# Altium schematic sheet Power.SchDoc(Power) (continued)
## Library data of the parts (continued)
R10 = RC0603JR-07300RL: Res Thick Film 0603 300 Ohm 5% 0.1W(1/10W) ±100ppm/C Pad SMD T/R; Manufacturer: Yageo Group; Power Dissipation: 100mW; Temperature Coefficient: 100ppm/°C; Value: 300Ω; Voltage Rating: 75V; Voltage Rating (DC): 50V; Case Code (Imperial): 0603; Case/Package: 0603; Category: Chip SMD Resistors; China RoHS: Non-Compliant; Component Kind: Standard; ComponentLink1Description: https://datasheet.octopart.com:443/RC0603JR-07300RL-Yageo-datasheet-175887523.pdf?src-supplier=IHS; ComponentLink1URL: https://datasheet.octopart.com:443/RC0603JR-07300RL-Yageo-datasheet-175887523.pdf?src-supplier=IHS; ComponentLink2Description: https://datasheet.octopart.com:443/RC0603JR-07300RL-Yageo-datasheet-98239111.pdf?src-supplier=Upverter; ComponentLink2URL: https://datasheet.octopart.com:443/RC0603JR-07300RL-Yageo-datasheet-98239111.pdf?src-supplier=Upverter; ComponentLink3Description: https://datasheet.octopart.com:443/RC0603JR-07300RL-Yageo-datasheet-8328172.pdf?src-supplier=TME; ComponentLink3URL: https://datasheet.octopart.com:443/RC0603JR-07300RL-Yageo-datasheet-8328172.pdf?src-supplier=TME; ComponentLink4Description: https://datasheet.octopart.com:443/RC0603JR-07300RL-Yageo-datasheet-34211833.pdf?src-supplier=element14+APAC; ComponentLink4URL: https://datasheet.octopart.com:443/RC0603JR-07300RL-Yageo-datasheet-34211833.pdf?src-supplier=element14+APAC; ComponentLink5Description: https://datasheet.octopart.com:443/RC0603JR-07300RL-Yageo-datasheet-62067350.pdf?src-supplier=Farnell; ComponentLink5URL: https://datasheet.octopart.com:443/RC0603JR-07300RL-Yageo-datasheet-62067350.pdf?src-supplier=Farnell; ComponentLink6Description: https://datasheet.octopart.com:443/RC0603JR-07300RL-Yageo-Group-datasheet-176638170.pdf?src-supplier=Future+Electronics; ComponentLink6URL: https://datasheet.octopart.com:443/RC0603JR-07300RL-Yageo-Group-datasheet-176638170.pdf?src-supplier=Future+Electronics; ComponentLink7Description: https://datasheet.octopart.com:443/RC0603JR-07300RL-Yageo-datasheet-164759695.pdf?src-supplier=Future+Electronics; ComponentLink7URL: https://datasheet.octopart.com:443/RC0603JR-07300RL-Yageo-datasheet-164759695.pdf?src-supplier=Future+Electronics; ComponentLink8Description: https://datasheet.octopart.com:443/RC0603JR-07300RL-Yageo-datasheet-137700087.pdf?src-supplier=Future+Electronics; ComponentLink8URL: https://datasheet.octopart.com:443/RC0603JR-07300RL-Yageo-datasheet-137700087.pdf?src-supplier=Future+Electronics; ComponentLink9Description: https://datasheet.octopart.com:443/RC0603JR-07300RL-Yageo-datasheet-15599030.pdf?src-supplier=Future+Electronics; ComponentLink9URL: https://datasheet.octopart.com:443/RC0603JR-07300RL-Yageo-datasheet-15599030.pdf?src-supplier=Future+Electronics; ComponentLink10Description: https://datasheet.octopart.com:443/RC0603JR-07300RL-Yageo-datasheet-12596169.pdf?src-supplier=Future+Electronics; ComponentLink10URL: https://datasheet.octopart.com:443/RC0603JR-07300RL-Yageo-datasheet-12596169.pdf?src-supplier=Future+Electronics; ComponentLink11Description: https://datasheet.octopart.com:443/RC0603JR-07300RL-Yageo-datasheet-8324193.pdf?src-supplier=Future+Electronics; ComponentLink11URL: https://datasheet.octopart.com:443/RC0603JR-07300RL-Yageo-datasheet-8324193.pdf?src-supplier=Future+Electronics; ComponentLink12Description: https://datasheet.octopart.com/RC0603JR-07300RL-Yageo-datasheet-73766026.pdf; ComponentLink12URL: https://datasheet.octopart.com/RC0603JR-07300RL-Yageo-datasheet-73766026.pdf; ComponentLink13Description: https://datasheet.octopart.com:443/RC0603JR-07300RL-Yageo-datasheet-118562.pdf?src-supplier=DigiKey; ComponentLink13URL: https://datasheet.octopart.com:443/RC0603JR-07300RL-Yageo-datasheet-118562.pdf?src-supplier=DigiKey; ComponentLink14Description: http://sigma.octopart.com:80/conflict_mineral_statement/Yageo-company-3.pdf?src-supplier=Compliance+Statements; ComponentLink14URL: http://sigma.octopart.com:80/conflict_mineral_statement/Yageo-company-3.pdf?src-supplier=Compliance+Statements; ComponentLink15Description: http://sigma.octopart.com:80/rohs_statement/Yageo-company-2.pdf?src-supplier=Compliance+Statements; ComponentLink15URL: http://sigma.octopart.com:80/rohs_statement/Yageo-company-2.pdf?src-supplier=Compliance+Statements; Composition: Thick Film; Country of Origin: Mainland China, Taiwan; Depth: 800µm; Export Control Classification Number (ECCN) Code: EAR99; Harmonized Tariff Schedule (HTS) Code: 8533.21.00.30; Height: 450µm; Introduction Date: 2004-09-22; Lead Free: Lead Free; Length: 1.6mm; Library Name: ENGG3800 schematic library.SchLib; Lifecycle Status: Production; Manufacturer Part Number: RC0603JR-07300RL; Max Operating Temperature: 155°C; Military Standard: Not; Min Operating Temperature: -55°C; Mounting Technology: Surface Mount; Number of Terminals: 2; Pins: 2; Power Rating: 100mW; Pricing: 5000=0.0015, 10000=0.0012 (USD); REACH SVHC: Yes; RoHS: Compliant; Schedule B: 8533210045, 8533210045|8533210045|8533210045|8533210045|8533210045|8533210045|8533210045|8533210045|8533210045|8533210045|8533210045|8533210045|8533210045|8533210045|8533210045|8533210045|8533210045|8533210045|8533210045|8533210045|8533210045|8533210045|8533210045|85; Stock: 640000; Supplier 1: Digikey; Supplier Part Number 1: 311-300GRCT-ND; Termination: SMD/SMT; Weight: 2.012816mg; Width: 800µm; Working Voltage: 75V
SW1 = CS22BNW03: Switch Slide ON None ON DPDT Top Slide 3A 250VAC 50000Cycles PC Pins Thru-Hole; Manufacturer: NKK Switches; Actuator Length: 5.1054mm; Actuator Material: Glass, Glass fibre, Nylon, Polyamide; Contact Resistance: 10mΩ; Contact Style: Non-Shorting; Contact Voltage Rating (AC): 125V; Electrical Life: 20kcycles; Illumination Type: Non-Illuminated; Insulation Resistance: 1GΩ; Max Voltage Rating (AC): 250V; Mechanical Life: 50kcycles; Number of Positions: 2; Sealable: EPOXY TERMINAL SEALED; Switch Function: On-On; Switch Travel: 2.2mm; Category: Slide Switches; China RoHS: Compliant; Component Kind: Standard; ComponentLink1Description: https://datasheet.octopart.com:443/CS22BNW03-NKK-Switches-datasheet-12526506.pdf?src-supplier=Upverter; ComponentLink1URL: https://datasheet.octopart.com:443/CS22BNW03-NKK-Switches-datasheet-12526506.pdf?src-supplier=Upverter; ComponentLink2Description: https://datasheet.octopart.com:443/CS22BNW03-NKK-Switches-datasheet-123473.pdf?src-supplier=Future+Electronics; ComponentLink2URL: https://datasheet.octopart.com:443/CS22BNW03-NKK-Switches-datasheet-123473.pdf?src-supplier=Future+Electronics; ComponentLink3Description: https://datasheet.octopart.com:443/CS22BNW03-NKK-Switches-datasheet-110754214.pdf?src-supplier=TME; ComponentLink3URL: https://datasheet.octopart.com:443/CS22BNW03-NKK-Switches-datasheet-110754214.pdf?src-supplier=TME; ComponentLink4Description: https://datasheet.octopart.com:443/CS22BNW03-NKK-Switches-datasheet-137092470.pdf?src-supplier=X-ON; ComponentLink4URL: https://datasheet.octopart.com:443/CS22BNW03-NKK-Switches-datasheet-137092470.pdf?src-supplier=X-ON; ComponentLink5Description: http://sigma.octopart.com:80/rohs_statement/NKK-Switches-company-77.pdf?src-supplier=Compliance+Statements; ComponentLink5URL: http://sigma.octopart.com:80/rohs_statement/NKK-Switches-company-77.pdf?src-supplier=Compliance+Statements; ComponentLink6Description: http://sigma.octopart.com:80/reach_statement/NKK-Switches-company-76.pdf?src-supplier=Compliance+Statements; ComponentLink6URL: http://sigma.octopart.com:80/reach_statement/NKK-Switches-company-76.pdf?src-supplier=Compliance+Statements; ComponentLink7Description: http://sigma.octopart.com:80/conflict_mineral_statement/NKK-Switches-company-75.pdf?src-supplier=Compliance+Statements; ComponentLink7URL: http://sigma.octopart.com:80/conflict_mineral_statement/NKK-Switches-company-75.pdf?src-supplier=Compliance+Statements; Contact Current Rating: 3A; Contact Material: Copper; Contact Plating: Silver; Current Rating: 3A; Depth: 11.9mm; Export Control Classification Number (ECCN) Code: EAR99; Flammability Rating: UL94 V-0; Harmonized Tariff Schedule (HTS) Code: 8536.50.90.50; Height: 9.7mm; Introduction Date: 2010-05-04; Lead Free: Lead Free; Length: 14mm; Library Name: ENGG3800 schematic library.SchLib; Life (Cycles): 20kcycles; Lifecycle Status: Production; Manufacturer Part Number: CS22BNW03; Max Current Rating: 3A; Max Operating Temperature: 85°C; Min Operating Temperature: -30°C; Mounting Technology: Through Hole; Orientation: Vertical; Pricing: 50=1.47, 150=1.46, 500=1.44, 1000=1.42, 2000=1.4 (USD); REACH SVHC: Yes; RoHS: Compliant; Stock: 789; Supplier 1: Digikey; Supplier Part Number 1: 360-2132-ND; Terminal Material: Copper; Termination: PC Pins; Throw Configuration: DPDT; Voltage Rating (AC): 125V
TP1 TP6 = 5010: MS27478 - Connectors Receptacle Electrical Solder Mounting Solder Type Hermetic Seal 38999 Series II; Manufacturer: Keystone Electronics; Body Material: Bronze,PBT; Hole Diameter: 1.6mm; Inside Diameter: 1.78mm; Plating: Silver; Category: Connectors; Color: Red; Component Kind: Standard; ComponentLink1Description: https://datasheet.ciiva.com/11744/0900766b8009b7c7-11744920.pdf?src-supplier=Rs; ComponentLink1URL: https://datasheet.ciiva.com/11744/0900766b8009b7c7-11744920.pdf?src-supplier=Rs; ComponentLink2Description: https://datasheet.ciiva.com/2917/5010-2917626.pdf?src-supplier=Digikey; ComponentLink2URL: https://datasheet.ciiva.com/2917/5010-2917626.pdf?src-supplier=Digikey; ComponentLink3Description: https://datasheet.ciiva.com/1454/1703983-1454833.pdf?src-supplier=Element14; ComponentLink3URL: https://datasheet.ciiva.com/1454/1703983-1454833.pdf?src-supplier=Element14; ComponentLink4Description: https://datasheet.ciiva.com/22631/1703983-22631113.pdf?src-supplier=Element14; ComponentLink4URL: https://datasheet.ciiva.com/22631/1703983-22631113.pdf?src-supplier=Element14; ComponentLink5Description: https://datasheet.ciiva.com/16506/m60-2p60-16506972.pdf?src-supplier=Future+Electronics; ComponentLink5URL: https://datasheet.ciiva.com/16506/m60-2p60-16506972.pdf?src-supplier=Future+Electronics; ComponentLink6Description: https://datasheet.ciiva.com/16883/70182825-16883886.pdf?src-supplier=Allied+Electronics; ComponentLink6URL: https://datasheet.ciiva.com/16883/70182825-16883886.pdf?src-supplier=Allied+Electronics; Contact Material: Bronze; Contact Plating: Silver,Tin; Flammability Rating: UL94 V-0; Height: 0.445inch; Insulation Material: Nylon; Lead Free: Lead Free; Length: 11.3mm; Manufacturer Part Number: 5010; Material: Nylon,Bronze,Silver; Mounting Technology: Through Hole,PCB; Package Quantity: 100; Packaging: Bulk; Pricing: 1=0.39, 10=0.293, 25=0.2624, 100=0.2223, 500=0.18446, 1000=0.1739, 2500=0.1542, 5000=0.14312 (USD); Reach SVHC Compliant: No; RoHS: Yes; RoHS Compliant: Yes; Series: THM; Stock: 151577; Supplier 1: Digikey; Supplier Part Number 1: 36-5010-ND; Termination: Through Hole; Library Name: tpmania.SchLib
TP2 = 5012: Test Point, Multipurpose, Thm, .350 L, .125 Od, Heat Resistant Nylon 46, White; Manufacturer: Keystone; Body Material: Bronze, PBT; Gender: Female; Hole Diameter: 1.6mm; Inside Diameter: 1.78mm; Insulation: Insulated; Number of Contacts: 1; Case/Package: 5012; Category: Test Points; Color: White; Component Kind: Standard; ComponentLink1Description: https://datasheet.octopart.com:443/5012-Keystone-datasheet-111020743.pdf?src-supplier=IHS; ComponentLink1URL: https://datasheet.octopart.com:443/5012-Keystone-datasheet-111020743.pdf?src-supplier=IHS; ComponentLink2Description: https://datasheet.octopart.com:443/5012-Keystone-datasheet-15716159.pdf?src-supplier=Farnell; ComponentLink2URL: https://datasheet.octopart.com:443/5012-Keystone-datasheet-15716159.pdf?src-supplier=Farnell; ComponentLink3Description: https://datasheet.octopart.com:443/5012-Keystone-datasheet-9590016.pdf?src-supplier=Sager+Electronics; ComponentLink3URL: https://datasheet.octopart.com:443/5012-Keystone-datasheet-9590016.pdf?src-supplier=Sager+Electronics; ComponentLink4Description: https://datasheet.octopart.com:443/5012-Keystone-datasheet-23674.pdf?src-supplier=element14; ComponentLink4URL: https://datasheet.octopart.com:443/5012-Keystone-datasheet-23674.pdf?src-supplier=element14; ComponentLink5Description: https://datasheet.octopart.com:443/5012-Keystone-datasheet-549598.pdf?src-supplier=Future+Electronics; ComponentLink5URL: https://datasheet.octopart.com:443/5012-Keystone-datasheet-549598.pdf?src-supplier=Future+Electronics; ComponentLink6Description: https://datasheet.octopart.com:443/5012-Keystone-datasheet-60278094.pdf?src-supplier=Future+Electronics; ComponentLink6URL: https://datasheet.octopart.com:443/5012-Keystone-datasheet-60278094.pdf?src-supplier=Future+Electronics; ComponentLink7Description: https://datasheet.octopart.com:443/5012-Keystone-datasheet-528293.pdf?src-supplier=Future+Electronics; ComponentLink7URL: https://datasheet.octopart.com:443/5012-Keystone-datasheet-528293.pdf?src-supplier=Future+Electronics; ComponentLink8Description: https://datasheet.octopart.com:443/5012-Keystone-datasheet-10925273.pdf?src-supplier=RS+(Formerly+Allied+Electronics); ComponentLink8URL: https://datasheet.octopart.com:443/5012-Keystone-datasheet-10925273.pdf?src-supplier=RS+(Formerly+Allied+Electronics); ComponentLink9Description: https://datasheet.octopart.com:443/5012-Keystone-datasheet-6528.pdf?src-supplier=RS+(Formerly+Allied+Electronics); ComponentLink9URL: https://datasheet.octopart.com:443/5012-Keystone-datasheet-6528.pdf?src-supplier=RS+(Formerly+Allied+Electronics); ComponentLink10Description: https://datasheet.octopart.com:443/5012-Keystone-datasheet-7282928.pdf?src-supplier=Jameco; ComponentLink10URL: https://datasheet.octopart.com:443/5012-Keystone-datasheet-7282928.pdf?src-supplier=Jameco; Contact Material: Bronze; Contact Plating: Silver; Country of Origin: Mainland China; Diameter: 3.18mm; Export Control Classification Number (ECCN) Code: EAR99; Flammability Rating: UL94 V-0; Height: 8.12mm; Housing Color: White; Introduction Date: 1995-10-01; Lead Free: Lead Free; Length: 11.3mm; Lifecycle Status: Production; Manufacturer Part Number: 5012; Material: Bronze, Nylon, Silver; Mounting Technology: Through Hole; Number of Ports: 1; Orientation: Straight; Packaging: Bulk; Pricing: 125=0.184, 250=0.181, 500=0.178, 1250=0.175, 2500=0.17 (USD); REACH SVHC: Yes; RoHS: Compliant; Stock: 1107; Supplier 1: Digikey; Supplier Part Number 1: 36-5012-ND; Termination: Through Hole; Library Name: tpmania.SchLib
TP3 = 5013: Test Point, Multipurpose, Thm, .350 L, .125 Od, Heat Resistant Nylon 46, Orange; Manufacturer: Keystone; Body Material: PBT; Inside Diameter: 1.78mm; Category: Test Points; Color: Orange; Component Kind: Standard; ComponentLink1Description: https://datasheet.octopart.com:443/5013-Keystone-datasheet-111020744.pdf?src-supplier=IHS; ComponentLink1URL: https://datasheet.octopart.com:443/5013-Keystone-datasheet-111020744.pdf?src-supplier=IHS; ComponentLink2Description: https://datasheet.octopart.com:443/5013-Keystone-datasheet-15716159.pdf?src-supplier=Farnell; ComponentLink2URL: https://datasheet.octopart.com:443/5013-Keystone-datasheet-15716159.pdf?src-supplier=Farnell; ComponentLink3Description: https://datasheet.octopart.com:443/5013-Keystone-datasheet-23674.pdf?src-supplier=element14; ComponentLink3URL: https://datasheet.octopart.com:443/5013-Keystone-datasheet-23674.pdf?src-supplier=element14; ComponentLink4Description: https://datasheet.octopart.com:443/5013-Keystone-datasheet-9590016.pdf?src-supplier=Sager+Electronics; ComponentLink4URL: https://datasheet.octopart.com:443/5013-Keystone-datasheet-9590016.pdf?src-supplier=Sager+Electronics; ComponentLink5Description: https://datasheet.octopart.com:443/5013-Keystone-datasheet-60278094.pdf?src-supplier=Future+Electronics; ComponentLink5URL: https://datasheet.octopart.com:443/5013-Keystone-datasheet-60278094.pdf?src-supplier=Future+Electronics; ComponentLink6Description: https://datasheet.octopart.com:443/5013-Keystone-datasheet-549598.pdf?src-supplier=Future+Electronics; ComponentLink6URL: https://datasheet.octopart.com:443/5013-Keystone-datasheet-549598.pdf?src-supplier=Future+Electronics; ComponentLink7Description: https://datasheet.octopart.com:443/5013-Keystone-datasheet-10925273.pdf?src-supplier=RS+(Formerly+Allied+Electronics); ComponentLink7URL: https://datasheet.octopart.com:443/5013-Keystone-datasheet-10925273.pdf?src-supplier=RS+(Formerly+Allied+Electronics); ComponentLink8Description: https://datasheet.octopart.com:443/5013-Keystone-datasheet-6528.pdf?src-supplier=RS+(Formerly+Allied+Electronics); ComponentLink8URL: https://datasheet.octopart.com:443/5013-Keystone-datasheet-6528.pdf?src-supplier=RS+(Formerly+Allied+Electronics); ComponentLink9Description: https://datasheet.octopart.com:443/5013-Keystone-datasheet-34151652.pdf?src-supplier=TME; ComponentLink9URL: https://datasheet.octopart.com:443/5013-Keystone-datasheet-34151652.pdf?src-supplier=TME; Contact Material: Bronze; Contact Plating: Silver; Country of Origin: Mainland China; Export Control Classification Number (ECCN) Code: EAR99; Flammability Rating: UL94 V-0; Height: 8.12mm; Introduction Date: 1995-10-01; Lead Free: Lead Free; Length: 11.3mm; Lifecycle Status: Production; Manufacturer Part Number: 5013; Material: Bronze, Nylon, Silver; Mounting Technology: Through Hole; Packaging: Bulk; Pricing: 125=0.173, 250=0.171, 500=0.169, 1500=0.165, 3000=0.16 (USD); REACH SVHC: Yes; RoHS: Compliant; Stock: 2691; Supplier 1: Digikey; Supplier Part Number 1: 36-5013-ND; Termination: Through Hole; Library Name: tpmania.SchLib
TP4 = 5014: MS27478 - Connectors Receptacle Electrical Solder Mounting Solder Type Hermetic Seal 38999 Series II; Manufacturer: Keystone Electronics; Body Material: Bronze,PBT; Inside Diameter: 1.78mm; Plating: Silver; Category: Connectors; Color: Yellow; Component Kind: Standard; ComponentLink1Description: https://datasheet.ciiva.com/2917/5014-2917636.pdf?src-supplier=Digikey; ComponentLink1URL: https://datasheet.ciiva.com/2917/5014-2917636.pdf?src-supplier=Digikey; ComponentLink2Description: https://datasheet.ciiva.com/22631/1703983-22631119.pdf?src-supplier=Element14; ComponentLink2URL: https://datasheet.ciiva.com/22631/1703983-22631119.pdf?src-supplier=Element14; ComponentLink3Description: https://datasheet.ciiva.com/16297/m60-2p60-16297225.pdf?src-supplier=Future+Electronics; ComponentLink3URL: https://datasheet.ciiva.com/16297/m60-2p60-16297225.pdf?src-supplier=Future+Electronics; ComponentLink4Description: https://datasheet.ciiva.com/16884/70182825-16884159.pdf?src-supplier=Allied+Electronics; ComponentLink4URL: https://datasheet.ciiva.com/16884/70182825-16884159.pdf?src-supplier=Allied+Electronics; Contact Material: Bronze; Contact Plating: Silver,Tin; Flammability Rating: UL94 V-0; Height: 0.445inch; Insulation Material: Nylon; Lead Free: Lead Free; Length: 11.3mm; Manufacturer Part Number: 5014; Material: Nylon,Bronze,Silver; Mounting Technology: PCB,Through Hole; Package Quantity: 100; Packaging: Bulk; Pricing: 1=0.39, 10=0.293, 25=0.2624, 100=0.2223, 500=0.18446, 1000=0.1739, 2500=0.1542, 5000=0.14312 (USD); Reach SVHC Compliant: No; RoHS: Yes; RoHS Compliant: Yes; Series: THM; Stock: 92393; Supplier: Digikey; Supplier Part Number: 36-5014-ND; Termination: Through Hole; Library Name: tpmania.SchLib
TP5 = 5011: TEST POINT, PCB, THROUGH HOLE; Product R; Range: -; Connector Colour: Black; Mounting: Through Hole; Manufacturer: Keystone Electronics; Body Material: PBT; Gender: Female; Hole Diameter: 1.6mm; Inside Diameter: 1.78mm; Category: Connectors; Color: Black; Component Kind: Standard; ComponentLink1Description: https://datasheet.ciiva.com/1454/1718230-1454834.pdf?src-supplier=Element14; ComponentLink1URL: https://datasheet.ciiva.com/1454/1718230-1454834.pdf?src-supplier=Element14; ComponentLink2Description: https://datasheet.ciiva.com/11744/0900766b8009b7c7-11744935.pdf?src-supplier=Rs; ComponentLink2URL: https://datasheet.ciiva.com/11744/0900766b8009b7c7-11744935.pdf?src-supplier=Rs; ComponentLink3Description: https://datasheet.ciiva.com/2917/5011-2917635.pdf?src-supplier=Digikey; ComponentLink3URL: https://datasheet.ciiva.com/2917/5011-2917635.pdf?src-supplier=Digikey; ComponentLink4Description: https://datasheet.ciiva.com/46412/1703983-46412138.pdf?src-supplier=Element14; ComponentLink4URL: https://datasheet.ciiva.com/46412/1703983-46412138.pdf?src-supplier=Element14; ComponentLink5Description: https://datasheet.ciiva.com/16351/m60-2p60-16351093.pdf?src-supplier=Future+Electronics; ComponentLink5URL: https://datasheet.ciiva.com/16351/m60-2p60-16351093.pdf?src-supplier=Future+Electronics; Contact Material: Bronze; Contact Plating: Tin,Silver; Flammability Rating: UL94 V-0; Lead Free: 5697LeadFree; Length: 11.3mm; Manufacturer Part Number: 5011; Material: Nylon,Bronze,Silver; Mounting Technology: PCB,Through Hole; Package Quantity: 100; Packaging: Bulk; Pricing: 1=0.39, 10=0.293, 25=0.2624, 100=0.2223, 500=0.18446, 1000=0.1739, 2500=0.1542, 5000=0.14312 (USD); Reach SVHC Compliant: No; RoHS: Yes; RoHS Compliant: Yes; Series: THM; Stock: 252020; Supplier: Digikey; Supplier Part Number: 36-5011-ND; Library Name: tpmania.SchLib
U2 = MCP73831T-2ACI/OT: MCP73831 Series Single-Cell Li-Ion/Li-Polymer Battery Charge Controller SOT-23-5; Manufacturer: Microchip; Manufacturer Lifecycle Status: REL; Drain to Source Resistance: 350mΩ; Max Charge Current: 500mA; Max Junction Temperature (Tj): 125°C; Max Output Voltage: 4.5V; Max Power Dissipation: 1.54W; Max Supply Voltage: 6V; Min Output Voltage: 4.168V; Min Supply Voltage: 3.75V; Number of Cells: 1; Output Voltage: 4.2V; Voltage Rating: 4.534V; Ambient Temperature Range High: 85°C; Case/Package: SOT-23; Category: Battery Management; Component Kind: Standard; ComponentLink1Description: https://datasheet.octopart.com:443/MCP73831T-2ACI-OT-Microchip-datasheet-8814540.pdf?src-supplier=element14+APAC; ComponentLink1URL: https://datasheet.octopart.com:443/MCP73831T-2ACI-OT-Microchip-datasheet-8814540.pdf?src-supplier=element14+APAC; ComponentLink2Description: https://datasheet.octopart.com:443/MCP73831T-2ACI-OT-Microchip-datasheet-145017548.pdf?src-supplier=Microchip; ComponentLink2URL: https://datasheet.octopart.com:443/MCP73831T-2ACI-OT-Microchip-datasheet-145017548.pdf?src-supplier=Microchip; ComponentLink3Description: https://datasheet.octopart.com:443/MCP73831T-2ACI-OT-Microchip-datasheet-34193478.pdf?src-supplier=Farnell; ComponentLink3URL: https://datasheet.octopart.com:443/MCP73831T-2ACI-OT-Microchip-datasheet-34193478.pdf?src-supplier=Farnell; ComponentLink4Description: https://datasheet.octopart.com:443/MCP73831T-2ACI-OT-Microchip-datasheet-16123459.pdf?src-supplier=LKR; ComponentLink4URL: https://datasheet.octopart.com:443/MCP73831T-2ACI-OT-Microchip-datasheet-16123459.pdf?src-supplier=LKR; ComponentLink5Description: https://datasheet.octopart.com:443/MCP73831T-2ACI-OT-Microchip-datasheet-142675560.pdf?src-supplier=TME; ComponentLink5URL: https://datasheet.octopart.com:443/MCP73831T-2ACI-OT-Microchip-datasheet-142675560.pdf?src-supplier=TME; ComponentLink6Description: https://datasheet.octopart.com:443/MCP73831T-2ACI-OT-Microchip-datasheet-158592061.pdf?src-supplier=SHENGYU+ELECTRONICS; ComponentLink6URL: https://datasheet.octopart.com:443/MCP73831T-2ACI-OT-Microchip-datasheet-158592061.pdf?src-supplier=SHENGYU+ELECTRONICS; ComponentLink7Description: https://datasheet.octopart.com/MCP73831T-2ACI-OT-Microchip-datasheet-16593012.pdf; ComponentLink7URL: https://datasheet.octopart.com/MCP73831T-2ACI-OT-Microchip-datasheet-16593012.pdf; ComponentLink8Description: https://datasheet.octopart.com:443/MCP73831T-2ACI-OT-Microchip-datasheet-7127743.pdf?src-supplier=Newark; ComponentLink8URL: https://datasheet.octopart.com:443/MCP73831T-2ACI-OT-Microchip-datasheet-7127743.pdf?src-supplier=Newark; ComponentLink9Description: https://datasheet.octopart.com:443/MCP73831T-2ACIOT-Microchip-datasheet-39874.pdf?src-supplier=RS+(Formerly+Allied+Electronics); ComponentLink9URL: https://datasheet.octopart.com:443/MCP73831T-2ACIOT-Microchip-datasheet-39874.pdf?src-supplier=RS+(Formerly+Allied+Electronics); ComponentLink10Description: http://sigma.octopart.com:80/188449423/materials_sheet/Microchip-MCP73831T-2ACI-OT.pdf?src-supplier=Compliance+Statements; ComponentLink10URL: http://sigma.octopart.com:80/188449423/materials_sheet/Microchip-MCP73831T-2ACI-OT.pdf?src-supplier=Compliance+Statements; ComponentLink11Description: http://sigma.octopart.com:80/192856963/materials_sheet/Microchip-MCP73831T-2ACI-OT.pdf?src-supplier=Compliance+Statements; ComponentLink11URL: http://sigma.octopart.com:80/192856963/materials_sheet/Microchip-MCP73831T-2ACI-OT.pdf?src-supplier=Compliance+Statements; ComponentLink12Description: http://sigma.octopart.com:80/rohs_statement/Microchip-company-71.pdf?src-supplier=Compliance+Statements; ComponentLink12URL: http://sigma.octopart.com:80/rohs_statement/Microchip-company-71.pdf?src-supplier=Compliance+Statements; ComponentLink13Description: http://sigma.octopart.com:80/reach_statement/Microchip-company-70.pdf?src-supplier=Compliance+Statements; ComponentLink13URL: http://sigma.octopart.com:80/reach_statement/Microchip-company-70.pdf?src-supplier=Compliance+Statements; ComponentLink14Description: http://sigma.octopart.com:80/conflict_mineral_statement/Microchip-company-69.pdf?src-supplier=Compliance+Statements; ComponentLink14URL: http://sigma.octopart.com:80/conflict_mineral_statement/Microchip-company-69.pdf?src-supplier=Compliance+Statements; ComponentLink15Description: http://sigma.octopart.com:80/conflict_mineral_statement/Microchip-company-68.pdf?src-supplier=Compliance+Statements; ComponentLink15URL: http://sigma.octopart.com:80/conflict_mineral_statement/Microchip-company-68.pdf?src-supplier=Compliance+Statements; Contact Plating: Tin; Country of Assembly: Thailand; Country of Fabrication: United States of America; Country of Origin: Thailand; Export Control Classification Number (ECCN) Code: EAR99; Harmonized Tariff Schedule (HTS) Code: 8542.39.0060; Height: 1.45mm; Introduction Date: 2005-11-03; Length: 3.0988mm; Library Name: ENGG3800 schematic library.SchLib; Lifecycle Status: Production; Manufacturer Part Number: MCP73831T-2ACI/OT; Max Operating Temperature: 85°C; Min Operating Temperature: -40°C; Mounting Technology: Surface Mount; Nominal Supply Voltage: 6V; Number of Channels: 1; Number of Outputs: 1; Output Current: 500mA; Packaging: Cut Tape; Pins: 5; Pricing: 3000=0.99, 6000=0.985, 12000=0.98, 24000=0.975, 48000=0.97 (USD); Projected EOL Date: 2034-11-03; Radiation Hardening: No; REACH SVHC: No; RoHS: Compliant; Schedule B: 8542390000, 8542390000|8542390000|8542390000|8542390000|8542390000; Stock: 21000; Supplier 1: Digikey; Supplier Part Number 1: MCP73831T-2ACI/OTCT-ND; Termination: SMD/SMT; Weight: 200.998119mg; Width: 1.8034mm
U3 = LM358DT: Integrated Circuit Operational Amplifier; CH: 2; 1.1MHz; 3-32V; 0+70 deg.C; SMD; SO8; Manufacturer: STMicroelectronics; Common Mode Rejection Ratio: 60dB; Dual Supply Voltage: 9V; Input Bias Current: 150nA; Input Offset Voltage (Vos): 9mV; Max Dual Supply Voltage: 15V; Max Junction Temperature (Tj): 150°C; Max Output current: 60mA; Max Supply Current: 2mA; Max Supply Voltage: 28.5V; Min Dual Supply Voltage: 1.5V; Min Input Voltage: 0V; Min Supply Voltage: 3V; Nominal Supply Current: 350µA; Number of Amplifiers: 2; Operating Supply Current: 700µA; Operating Supply Voltage: 5V; Slew Rate: 0.3V/µs; Voltage Gain: 100dB; Bandwidth: 1.1MHz; Case/Package: SOP; Category: Amplifiers - Op Amps, Buffer, Instrumentation; China RoHS: Compliant; Component Kind: Standard; ComponentLink1Description: https://datasheet.octopart.com:443/LM358DT-STMicroelectronics-datasheet-116606904.pdf?src-supplier=ODG+(Origin+Data+Global); ComponentLink1URL: https://datasheet.octopart.com:443/LM358DT-STMicroelectronics-datasheet-116606904.pdf?src-supplier=ODG+(Origin+Data+Global); ComponentLink2Description: https://datasheet.octopart.com:443/LM358DT-STMicroelectronics-datasheet-61210252.pdf?src-supplier=element14+APAC; ComponentLink2URL: https://datasheet.octopart.com:443/LM358DT-STMicroelectronics-datasheet-61210252.pdf?src-supplier=element14+APAC; ComponentLink3Description: https://datasheet.octopart.com:443/LM358DT-STMicroelectronics-datasheet-11005313.pdf?src-supplier=STMicroelectronics; ComponentLink3URL: https://datasheet.octopart.com:443/LM358DT-STMicroelectronics-datasheet-11005313.pdf?src-supplier=STMicroelectronics; ComponentLink4Description: https://datasheet.octopart.com:443/LM358DT-STMicroelectronics-datasheet-10410245.pdf?src-supplier=Future+Electronics; ComponentLink4URL: https://datasheet.octopart.com:443/LM358DT-STMicroelectronics-datasheet-10410245.pdf?src-supplier=Future+Electronics; ComponentLink5Description: https://datasheet.octopart.com:443/LM358DT-STMicroelectronics-datasheet-529748.pdf?src-supplier=element14; ComponentLink5URL: https://datasheet.octopart.com:443/LM358DT-STMicroelectronics-datasheet-529748.pdf?src-supplier=element14; ComponentLink6Description: https://datasheet.octopart.com:443/LM358DT-STMicroelectronics-datasheet-109535.pdf?src-supplier=element14; ComponentLink6URL: https://datasheet.octopart.com:443/LM358DT-STMicroelectronics-datasheet-109535.pdf?src-supplier=element14; ComponentLink7Description: https://datasheet.octopart.com:443/LM358DT-STMicroelectronics-datasheet-81668593.pdf?src-supplier=SHENGYU+ELECTRONICS; ComponentLink7URL: https://datasheet.octopart.com:443/LM358DT-STMicroelectronics-datasheet-81668593.pdf?src-supplier=SHENGYU+ELECTRONICS; ComponentLink8Description: https://datasheet.octopart.com:443/LM358DT-STMicroelectronics-datasheet-36993016.pdf?src-supplier=TME; ComponentLink8URL: https://datasheet.octopart.com:443/LM358DT-STMicroelectronics-datasheet-36993016.pdf?src-supplier=TME; ComponentLink9Description: https://datasheet.octopart.com:443/LM358DT-STMicroelectronics-datasheet-57.pdf?src-supplier=Newark; ComponentLink9URL: https://datasheet.octopart.com:443/LM358DT-STMicroelectronics-datasheet-57.pdf?src-supplier=Newark; ComponentLink10Description: https://datasheet.octopart.com:443/LM358DT-STMicroelectronics-datasheet-15994790.pdf?src-supplier=Newark; ComponentLink10URL: https://datasheet.octopart.com:443/LM358DT-STMicroelectronics-datasheet-15994790.pdf?src-supplier=Newark; ComponentLink11Description: https://datasheet.octopart.com:443/LM358DT-STMicroelectronics-datasheet-14137276.pdf?src-supplier=iiiC; ComponentLink11URL: https://datasheet.octopart.com:443/LM358DT-STMicroelectronics-datasheet-14137276.pdf?src-supplier=iiiC; ComponentLink12Description: https://datasheet.octopart.com:443/LM358DT-STMicroelectronics-datasheet-9802834.pdf?src-supplier=RS+(Formerly+Allied+Electronics); ComponentLink12URL: https://datasheet.octopart.com:443/LM358DT-STMicroelectronics-datasheet-9802834.pdf?src-supplier=RS+(Formerly+Allied+Electronics); ComponentLink13Description: https://datasheet.octopart.com:443/LM358DT-STMicroelectronics-datasheet-5352292.pdf?src-supplier=Farnell; ComponentLink13URL: https://datasheet.octopart.com:443/LM358DT-STMicroelectronics-datasheet-5352292.pdf?src-supplier=Farnell; Contact Plating: Tin; Country of Origin: Mainland China; Export Control Classification Number (ECCN) Code: EAR99; Gain Bandwidth Product: 1.1MHz; Harmonized Tariff Schedule (HTS) Code: 8542.33.00.01; Height: 1.75mm; Height - Seated (Max): 1.75mm; Introduction Date: 1980-01-04; Lead Free: Lead Free; Length: 4.9mm; Library Name: ENGG3800 schematic library.SchLib; Lifecycle Status: Production; Manufacturer Part Number: LM358DT; Max Operating Temperature: 70°C; Min Operating Temperature: 0°C; Mounting Technology: Surface Mount; Number of Channels: 2; Number of Circuits: 2; Number of Elements: 2; Number of Terminals: 2; Output Current: 60mA; Output Current per Channel: 60mA; Packaging: Tape and Reel; Pins: 8; Pricing: 2500=0.0538, 5000=0.05346, 10000=0.05247, 20000=0.05152, 40000=0.0506 (USD); Radiation Hardening: No; REACH SVHC: Yes; RoHS: Compliant; Schedule B: 8542330000, 8542330000|8542330000|8542330000|8542330000|8542330000|8542330000|8542330000|8542330000|8542330000|8542330000|8542330000|8542330000|8542330000|8542330000|8542330000|8542330000|8542330000|8542330000|8542330000|8542330000|8542330000|8542330000|8542330000|85; Stock: 97500; Supplier 1: Digikey; Supplier Part Number 1: 497-1591-1-ND; Temperature Grade: Commercial; Terminal Pitch: 1.27mm; Unity Gain Bandwidth Product: 1.1MHz; Weight: 4.535924g; Width: 3.9mm
U4 = AP7361C-33Y5-13: AP7361 Series 3.3 V 1 A ±1% 80 µA 75 dB Enable LDO Regulator IC - SOT-89-5; Manufacturer: Diodes Inc.; Dropout Voltage: 360mV; Max Junction Temperature (Tj): 150°C; Max Supply Voltage: 6V; Min Current Limit: 1.1A; Min Input Voltage: 4.3V; Number of Channels: 1; Output Current: 1A; Output Voltage: 3.3V; Case/Package: SOT-89-5; Category: LDO Voltage Regulators; China RoHS: Compliant; Component Kind: Standard; ComponentLink1Description: https://datasheet.octopart.com:443/AP7361C-33Y5-13-Diodes-Inc.-datasheet-159616639.pdf?src-supplier=IHS; ComponentLink1URL: https://datasheet.octopart.com:443/AP7361C-33Y5-13-Diodes-Inc.-datasheet-159616639.pdf?src-supplier=IHS; ComponentLink2Description: https://datasheet.octopart.com:443/AP7361C-33Y5-13-Diodes-Inc.-datasheet-101745716.pdf?src-supplier=Future+Electronics; ComponentLink2URL: https://datasheet.octopart.com:443/AP7361C-33Y5-13-Diodes-Inc.-datasheet-101745716.pdf?src-supplier=Future+Electronics; ComponentLink3Description: https://datasheet.octopart.com:443/AP7361C-33Y5-13-Diodes-Inc.-datasheet-158996477.pdf?src-supplier=SHENGYU+ELECTRONICS; ComponentLink3URL: https://datasheet.octopart.com:443/AP7361C-33Y5-13-Diodes-Inc.-datasheet-158996477.pdf?src-supplier=SHENGYU+ELECTRONICS; Country of Origin: Mainland China; Export Control Classification Number (ECCN) Code: EAR99; Harmonized Tariff Schedule (HTS) Code: 8542.39.00.60; Height - Seated (Max): 1.6mm; Introduction Date: 2015-10-13; Length: 4.5mm; Library Name: ENGG3800 schematic library.SchLib; Lifecycle Status: Production; Manufacturer Part Number: AP7361C-33Y5-13; Max Operating Temperature: 85°C; Min Operating Temperature: -40°C; Mounting Technology: Surface Mount; Number of Circuits: 1; Number of Regulators: 1; Number of Terminals: 5; Pricing: 1=0.51, 10=0.359, 50=0.32, 100=0.278, 1000=0.236, 10000=0.218 (USD); REACH SVHC: Yes; RoHS: Compliant; Schedule B: 8542390000, 8542390000|8542390000|8542390000|8542390000|8542390000; Stock: 3716; Supplier 1: Digikey; Supplier Part Number 1: AP7361C-33Y5-13DICT-ND; Terminal Pitch: 1.5mm; Voltage Tolerance: 1%; Width: 2.5mm
U5 = RP604K501A-TR: Switching Regulator, Voltage-mode, 0.3A, PDSMALL OUTLINE, HEAT SINK/SLUG, ULTRA THIN PROFILE12; Manufacturer: Nisshinbo Micro Devices Inc.; Max Output current: 300mA; Max Output Voltage: 5.074V; Max Supply Voltage: 5.5V; Min Input Voltage: 1.8V; Min Output Voltage: 4.925V; Nominal Output Voltage: 5V; Nominal Supply Voltage: 3.6V; Number of Circuits: 1; Topology: Buck-Boost; Category: Voltage Regulators - Switching; Component Kind: Standard; ComponentLink1Description: https://datasheet.octopart.com:443/RP604K501A-TR-Nisshinbo-Micro-Devices-Inc.-datasheet-180927449.pdf?src-supplier=IHS; ComponentLink1URL: https://datasheet.octopart.com:443/RP604K501A-TR-Nisshinbo-Micro-Devices-Inc.-datasheet-180927449.pdf?src-supplier=IHS; ComponentLink2Description: https://datasheet.octopart.com:443/RP604K501A-TR-Nisshinbo-Micro-Devices-Inc.-datasheet-180921615.pdf?src-supplier=IHS; ComponentLink2URL: https://datasheet.octopart.com:443/RP604K501A-TR-Nisshinbo-Micro-Devices-Inc.-datasheet-180921615.pdf?src-supplier=IHS; ComponentLink3Description: https://datasheet.octopart.com:443/RP604K501A-TR-Ricoh-datasheet-181206399.pdf?src-supplier=IHS; ComponentLink3URL: https://datasheet.octopart.com:443/RP604K501A-TR-Ricoh-datasheet-181206399.pdf?src-supplier=IHS; Contact Plating: Gold; Export Control Classification Number (ECCN) Code: EAR99; Harmonized Tariff Schedule (HTS) Code: 8542.39.00.60; Height - Seated (Max): 600µm; Introduction Date: 2017-11-28; Length: 3mm; Library Name: ENGG3800 schematic library.SchLib; Lifecycle Status: Production; Manufacturer Part Number: RP604K501A-TR; Max Operating Temperature: 85°C; Min Operating Temperature: -40°C; Number of Terminals: 12; Pricing: 1=1.96, 10=1.448, 25=1.3188, 100=1.1767, 250=1.109, 500=1.1016 (USD); REACH SVHC: Yes; RoHS: Compliant; Stock: 1408; Supplier 1: DigiKey; Supplier Part Number 1: 2129-RP604K501A-CT-ND; Terminal Pitch: 500µm; Width: 2.7mm
